# Altium schematic sheet Output_Connectors.SchDoc
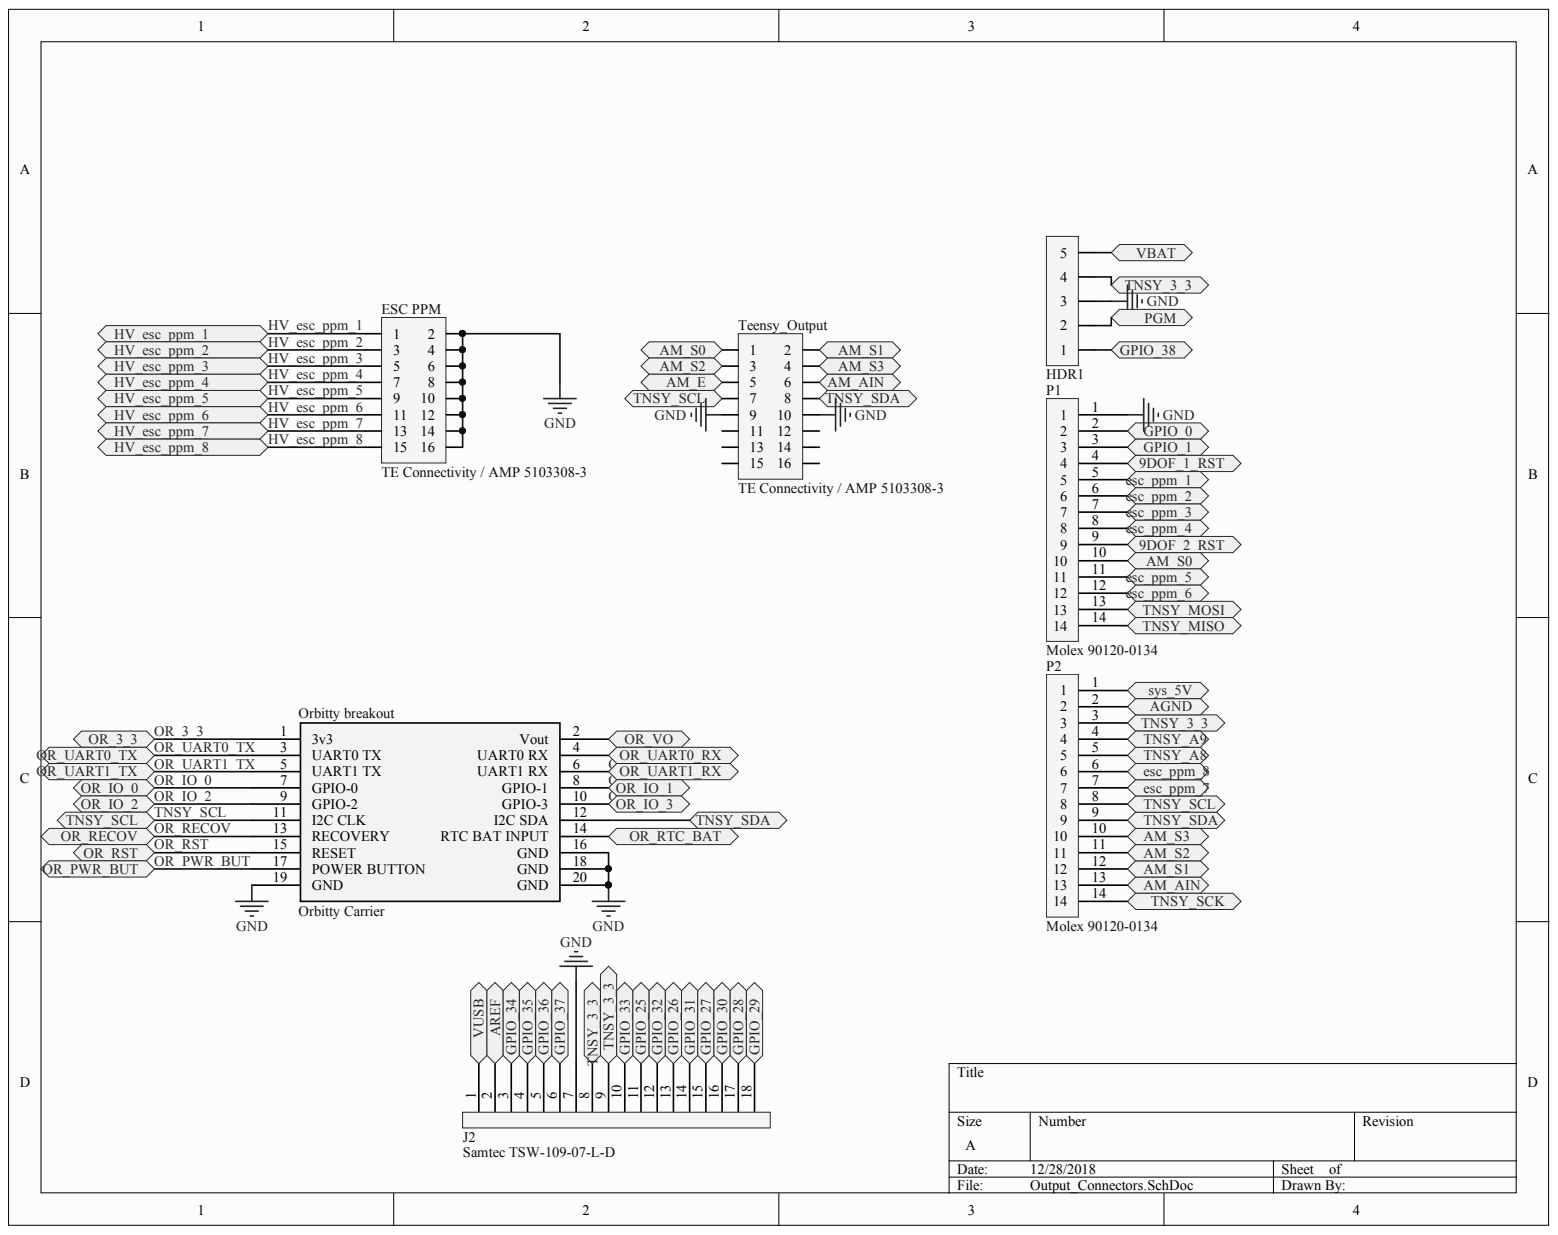
[diagram p0: Output_Connectors.SchDoc]

## Parts
ESC_PPM  TE Connectivity / AMP 5103308-3  [PCB-ez7c9rqqhu21cqzcwvs2-1]
HDR1  SYM-e94421bb99c43683-14  [PCB-0f2d16zq38ebt9jgapf2-1]  (value not drawn)
J2  Samtec TSW-109-07-L-D  [PCB-e7pjnkntgxce9rgxtjlg-1]
Orbitty_breakout  Orbitty Carrier  [PCB-xe8wxk2czp6dvao0bk5e-1]
P1  Molex 90120-0134  Manufacturer Part Number: 90120-0134  [SIP14]
P2  Molex 90120-0134  Manufacturer Part Number: 90120-0134  [SIP14]
Teensy_Output  TE Connectivity / AMP 5103308-3  [PCB-ez7c9rqqhu21cqzcwvs2-1]

## Ports
9DOF_1_RST, 9DOF_2_RST, AGND, AM_AIN, AM_E, AM_S0, AM_S1, AM_S2, AM_S3, AREF, ESC_PPM_1, ESC_PPM_2, ESC_PPM_3, ESC_PPM_4, ESC_PPM_5, ESC_PPM_6, ESC_PPM_7, ESC_PPM_8, GPIO_0, GPIO_1, GPIO_25, GPIO_26, GPIO_27, GPIO_28, GPIO_29, GPIO_30, GPIO_31, GPIO_32, GPIO_33, GPIO_34, GPIO_35, GPIO_36, GPIO_37, GPIO_38, HV_ESC_PPM_1, HV_ESC_PPM_2, HV_ESC_PPM_3, HV_ESC_PPM_4, HV_ESC_PPM_5, HV_ESC_PPM_6, HV_ESC_PPM_7, HV_ESC_PPM_8, OR_3_3, OR_IO_0, OR_IO_1, OR_IO_2, OR_IO_3, OR_PWR_BUT, OR_RECOV, OR_RST, OR_RTC_BAT, OR_UART0_RX, OR_UART0_TX, OR_UART1_RX, OR_UART1_TX, OR_VO, PGM, SYS_5V, TNSY_3_3, TNSY_A8, TNSY_A9, TNSY_MISO, TNSY_MOSI, TNSY_SCK, TNSY_SCL, TNSY_SDA, VBAT, VUSB

## Nets
ESC_PPM_1: P1.5 ; port ESC_PPM_1
ESC_PPM_2: P1.6 ; port ESC_PPM_2
ESC_PPM_3: P1.7 ; port ESC_PPM_3
ESC_PPM_4: P1.8 ; port ESC_PPM_4
ESC_PPM_5: P1.11 ; port ESC_PPM_5
ESC_PPM_6: P1.12 ; port ESC_PPM_6
ESC_PPM_7: P2.7 ; port ESC_PPM_7
ESC_PPM_8: P2.6 ; port ESC_PPM_8
GND: ESC_PPM.2 ESC_PPM.4 ESC_PPM.6 ESC_PPM.8 ESC_PPM.10 ESC_PPM.12 ESC_PPM.14 ESC_PPM.16 HDR1.3 J2.7 Orbitty_breakout.16(GND) Orbitty_breakout.18(GND) Orbitty_breakout.19(GND) Orbitty_breakout.20(GND) P1.1 Teensy_Output.9 Teensy_Output.10
SYS_5V: P2.1 ; port SYS_5V
9DOF_1_RST: P1.4 ; port 9DOF_1_RST
9DOF_2_RST: P1.9 ; port 9DOF_2_RST
AGND: P2.2 ; port AGND
AM_AIN: P2.13 Teensy_Output.6 ; port AM_AIN
AM_E: Teensy_Output.5 ; port AM_E
AM_S0: P1.10 Teensy_Output.1 ; port AM_S0
AM_S1: P2.12 Teensy_Output.2 ; port AM_S1
AM_S2: P2.11 Teensy_Output.3 ; port AM_S2
AM_S3: P2.10 Teensy_Output.4 ; port AM_S3
AREF: J2.2 ; port AREF
GPIO_0: P1.2 ; port GPIO_0
GPIO_1: P1.3 ; port GPIO_1
GPIO_25: J2.11 ; port GPIO_25
GPIO_26: J2.13 ; port GPIO_26
GPIO_27: J2.15 ; port GPIO_27
GPIO_28: J2.17 ; port GPIO_28
GPIO_29: J2.18 ; port GPIO_29
GPIO_30: J2.16 ; port GPIO_30
GPIO_31: J2.14 ; port GPIO_31
GPIO_32: J2.12 ; port GPIO_32
GPIO_33: J2.10 ; port GPIO_33
GPIO_34: J2.3 ; port GPIO_34
GPIO_35: J2.4 ; port GPIO_35
GPIO_36: J2.5 ; port GPIO_36
GPIO_37: J2.6 ; port GPIO_37
GPIO_38: HDR1.1 ; port GPIO_38
HV_ESC_PPM_1: ESC_PPM.1 ; port HV_ESC_PPM_1
HV_ESC_PPM_2: ESC_PPM.3 ; port HV_ESC_PPM_2
HV_ESC_PPM_3: ESC_PPM.5 ; port HV_ESC_PPM_3
HV_ESC_PPM_4: ESC_PPM.7 ; port HV_ESC_PPM_4
HV_ESC_PPM_5: ESC_PPM.9 ; port HV_ESC_PPM_5
HV_ESC_PPM_6: ESC_PPM.11 ; port HV_ESC_PPM_6
HV_ESC_PPM_7: ESC_PPM.13 ; port HV_ESC_PPM_7
HV_ESC_PPM_8: ESC_PPM.15 ; port HV_ESC_PPM_8
OR_3_3: Orbitty_breakout.1(3v3) ; port OR_3_3
OR_IO_0: Orbitty_breakout.7(GPIO-0) ; port OR_IO_0
OR_IO_1: Orbitty_breakout.8(GPIO-1) ; port OR_IO_1
OR_IO_2: Orbitty_breakout.9(GPIO-2) ; port OR_IO_2
OR_IO_3: Orbitty_breakout.10(GPIO-3) ; port OR_IO_3
OR_PWR_BUT: Orbitty_breakout.17(POWER_BUTTON) ; port OR_PWR_BUT
OR_RECOV: Orbitty_breakout.13(RECOVERY) ; port OR_RECOV
OR_RST: Orbitty_breakout.15(RESET) ; port OR_RST
OR_RTC_BAT: Orbitty_breakout.14(RTC_BAT_INPUT) ; port OR_RTC_BAT
OR_UART0_RX: Orbitty_breakout.4(UART0_RX) ; port OR_UART0_RX
OR_UART0_TX: Orbitty_breakout.3(UART0_TX) ; port OR_UART0_TX
OR_UART1_RX: Orbitty_breakout.6(UART1_RX) ; port OR_UART1_RX
OR_UART1_TX: Orbitty_breakout.5(UART1_TX) ; port OR_UART1_TX
OR_VO: Orbitty_breakout.2(Vout) ; port OR_VO
PGM: HDR1.2 ; port PGM
TNSY_3_3: HDR1.4 J2.8 J2.9 P2.3 ; port TNSY_3_3
TNSY_A8: P2.5 ; port TNSY_A8
TNSY_A9: P2.4 ; port TNSY_A9
TNSY_MISO: P1.14 ; port TNSY_MISO
TNSY_MOSI: P1.13 ; port TNSY_MOSI
TNSY_SCK: P2.14 ; port TNSY_SCK
TNSY_SCL: Orbitty_breakout.11(I2C_CLK) P2.8 Teensy_Output.7 ; port TNSY_SCL
TNSY_SDA: Orbitty_breakout.12(I2C_SDA) P2.9 Teensy_Output.8 ; port TNSY_SDA
VBAT: HDR1.5 ; port VBAT
VUSB: J2.1 ; port VUSB

Not connected: Teensy_Output 11 12 13 14 15 16

## Library data of the parts
ESC_PPM Teensy_Output = CMP-697ba22bb0a4b6bc-2: Wire-To-Board Connector, AMP-LATCH Series, Through Hole, Header, 16, 2.54 mm, Gold Plated Contacts; Pin Pitch: 2.54 mm; Color: Black; Component Kind: Standard; Contact Plating: Gold Flash, Tin over Nickel, Tin; Housing Color: Black; Housing Material: Thermoplastic; Library Name: CommunityVault; Mounting Style: Through Hole, Vertical; Octopart URL: http://octopart.com/5103308-3-te+connectivity+%2F+amp-39517369; Size-Height: 9.78 mm
HDR1 = CMP-e94421bb99c43683-16: Board-To-Board Connector, Vertical, Wr-Phd Series, Through Hole, Header, 5, 2.54 Mm; Current Rating: 3.00 A; Component Kind: Standard; Library Name: CommunityVault; Mounting Style: Through Hole; Number of Pins: 5; OctopartID: e94421bb99c43683; Orientation: Vertical; Packaging: Bag
J2 = CMP-3dcff4c13fd7e208-2: Conn Unshrouded Header HDR 18 POS 2.54mm Solder ST Thru-Hole; Component Kind: Standard; Library Name: CommunityVault; Mounting Style: Through Hole; Pin Pitch: 2.54 mm; Size-Length: 22.9 mm
Orbitty_breakout = CMP-066mcevbinch933dgmz7-2: Orbitty Carrier for NVIDIA® Jetson; Component Kind: Standard; CustomComponentID: 066mcevbinch933dgmz7; Library Name: FavoritesLibrary
P1 P2 = CMP-9dc954c8769c420e-2: 2.54mm Pitch C-Grid III™ Header, Single Row, Vertical, 14 Circuits, Tin (Sn) Plating; Current Rating: 3.00 A; Glow Wire Compliant: Non-Compliant; Insulation Resistance: 5.00 GΩ; Number of Circuits: 14; Voltage Rating (DC): 350 V; Color: Black; Component Kind: Standard; Contact Material: Brass; Contact Plating: Tin; Flammability Rating: UL 94 V-2; Halogen Free Status: Not Low Halogen; Housing Material: Polyester; Library Name: CommunityVault; Lifecycle Status: Active; Mounting Style: Through Hole; Number of Positions: 14; Number of Rows: 1; OctopartID: 9dc954c8769c420e; Octopart URL: http://octopart.com/90120-0134-molex-773683; Orientation: Vertical; Packaging: Bulk; Pin Pitch: 2.54 mm; REACH SVHC Compliance: No SVHC; RoHS: Compliant
